# Altium schematic sheet pad.SchDoc(pad)
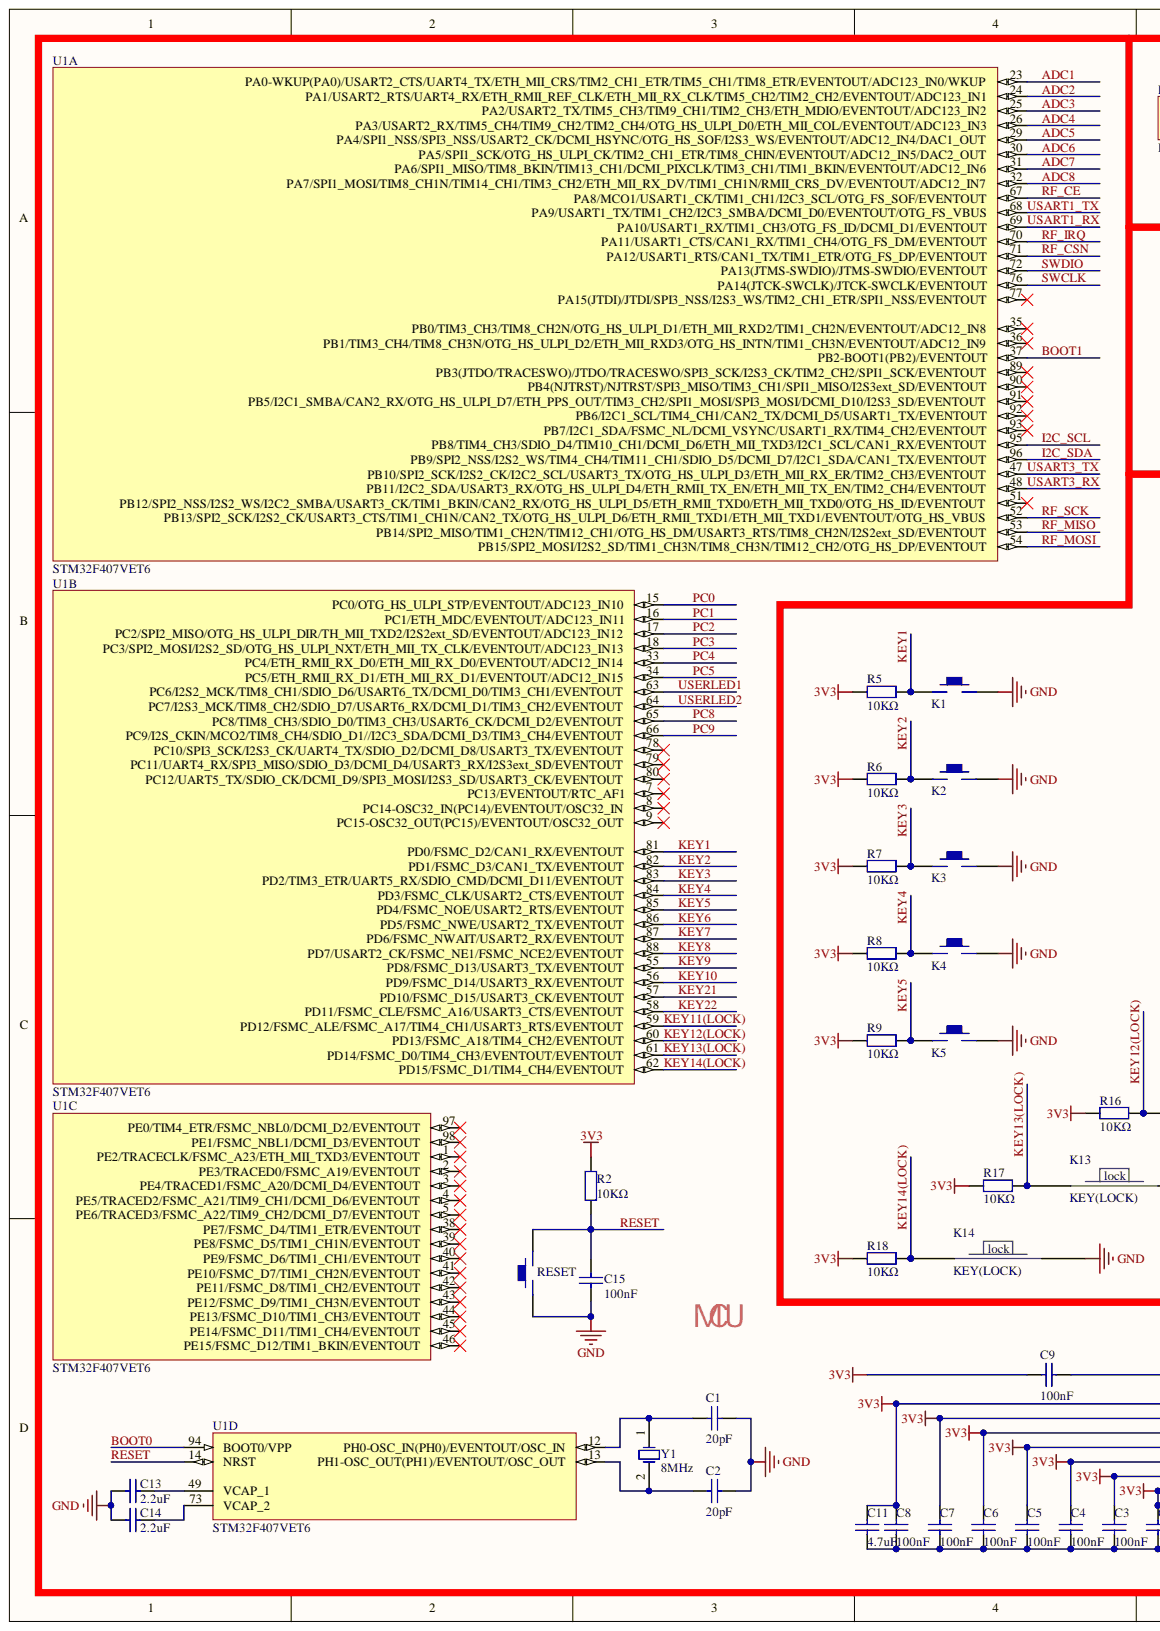
[diagram p0: pad.SchDoc, left]
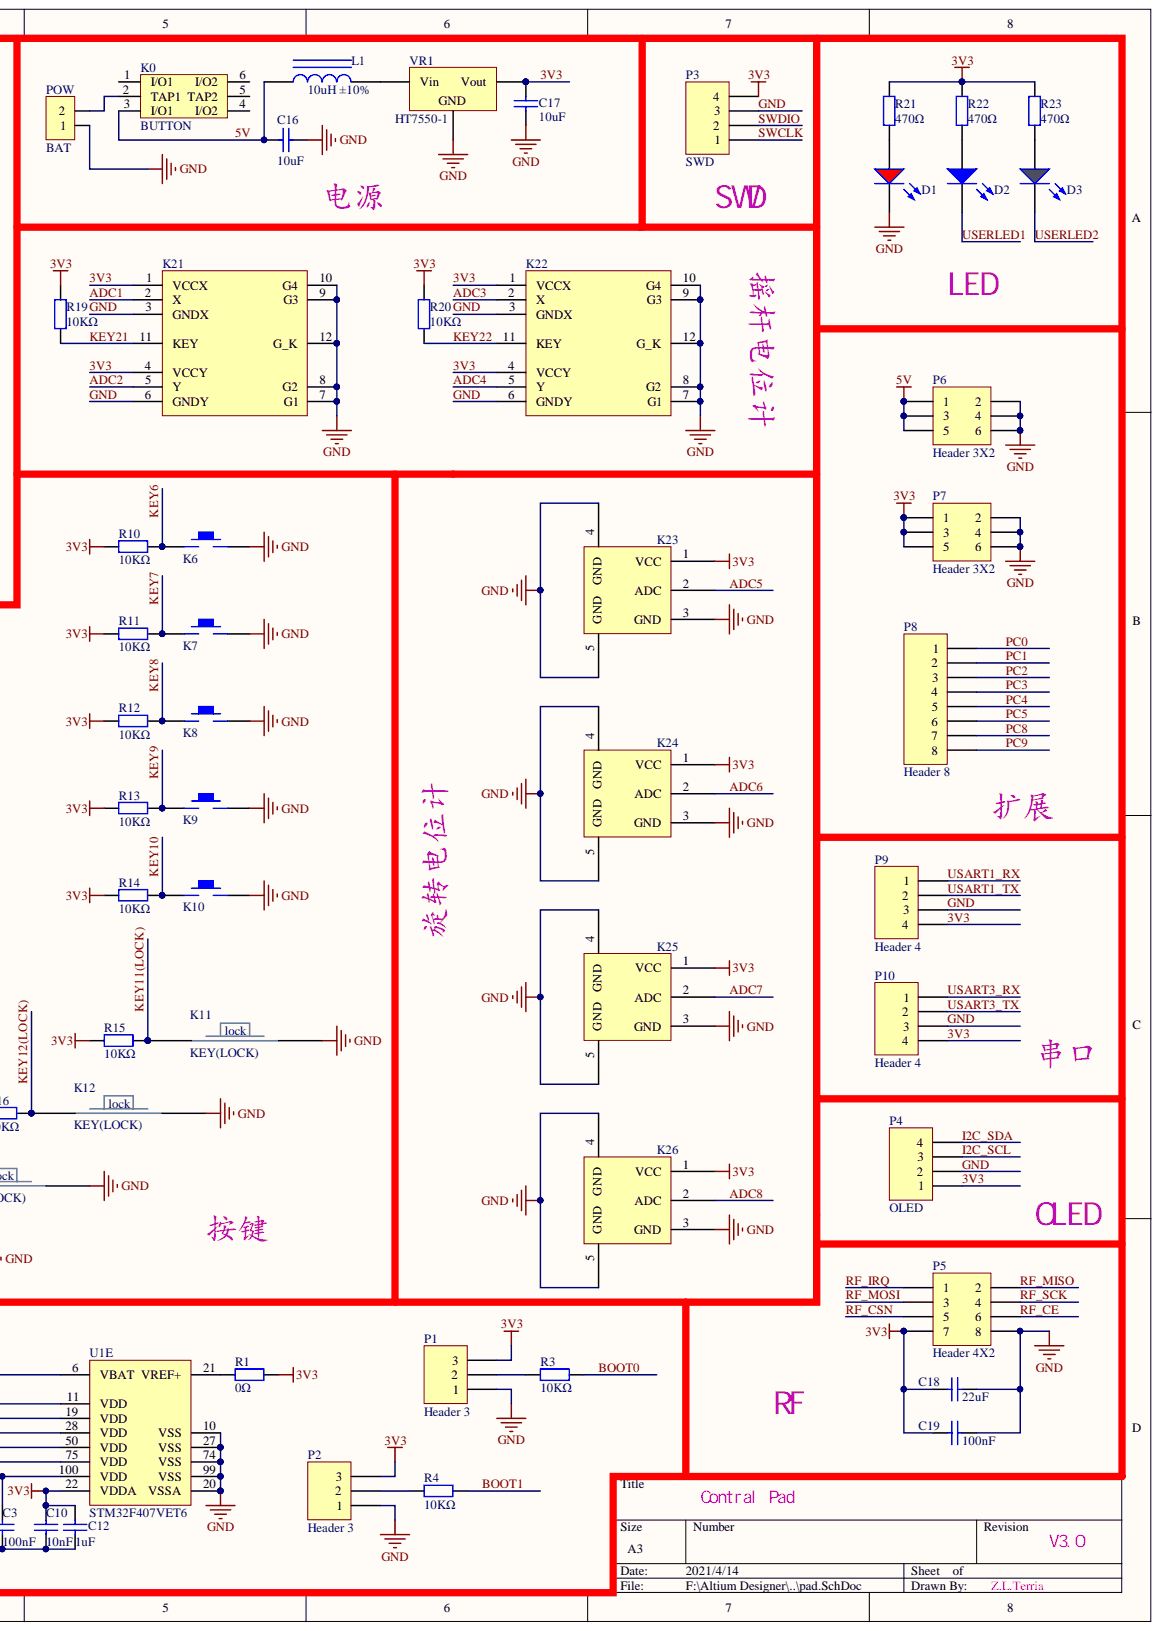
[diagram p1: pad.SchDoc, right]

## Parts
C1  20pF  [0603_C]
C2  20pF  [0603_C]
C3  100nF  [0603_C]
C4  100nF  [0603_C]
C5  100nF  [0603_C]
C6  100nF  [0603_C]
C7  100nF  [0603_C]
C8  100nF  [0603_C]
C9  100nF  [0603_C]
C10  10nF  [0603_C]
C11  4.7uF  [0603_C]
C12  1uF  [0603_C]
C13  2.2uF  [0603_C]
C14  2.2uF  [0603_C]
C15  100nF  [0603_C]
C16  10uF  [0603_C]
C17  10uF  [0603_C]
C18  22uF  [0603_C]
C19  100nF  [0603_C]
D1  红灯 0603  [0603_LED_S1]  (value not drawn)
D2  蓝灯 0603  [0603_LED_S1]  (value not drawn)
D3  绿灯 0603  [0603_LED_S1]  (value not drawn)
K0  BUTTON  [BTN8.5*8.5]
K1  KEY_M  [KEY_M]  (value not drawn)
K2  KEY_M  [KEY_M]  (value not drawn)
K3  KEY_M  [KEY_M]  (value not drawn)
K4  KEY_M  [KEY_M]  (value not drawn)
K5  KEY_M  [KEY_M]  (value not drawn)
K6  KEY_M  [KEY_M]  (value not drawn)
K7  KEY_M  [KEY_M]  (value not drawn)
K8  KEY_M  [KEY_M]  (value not drawn)
K9  KEY_M  [KEY_M]  (value not drawn)
K10  KEY_M  [KEY_M]  (value not drawn)
K11  KEY(LOCK)  [自锁按键]
K12  KEY(LOCK)  [自锁按键]
K13  KEY(LOCK)  [自锁按键]
K14  KEY(LOCK)  [自锁按键]
K21  摇杆电位计  [摇杆电位计]  (value not drawn)
K22  摇杆电位计  [摇杆电位计]
K23  KEY  [旋转电位计]  (value not drawn)
K24  KEY  [旋转电位计]  (value not drawn)
K25  KEY  [旋转电位计]  (value not drawn)
K26  KEY  [旋转电位计]  (value not drawn)
L1  10uH ±10%  [0603_L]
P1  Header 3  [HDR1X3]
P2  Header 3  [HDR1X3]
P3  SWD  [HDR1X4]
P4  OLED  [HDR1X4]
P5  Header 4X2  [HDR2X4]
P6  Header 3X2  [HDR2X3]
P7  Header 3X2  [HDR2X3]
P8  Header 8  [HDR1X8]
P9  Header 4  [HDR1X4]
P10  Header 4  [HDR1X4]
POW  BAT  [XH-2]
R1  0Ω  [0603_R]
R2  10KΩ  [0603_R]
R3  10KΩ  [0603_R]
R4  10KΩ  [0603_R]
R5  10KΩ  [0603_R]
R6  10KΩ  [0603_R]
R7  10KΩ  [0603_R]
R8  10KΩ  [0603_R]
R9  10KΩ  [0603_R]
R10  10KΩ  [0603_R]
R11  10KΩ  [0603_R]
R12  10KΩ  [0603_R]
R13  10KΩ  [0603_R]
R14  10KΩ  [0603_R]
R15  10KΩ  [0603_R]
R16  10KΩ  [0603_R]
R17  10KΩ  [0603_R]
R18  10KΩ  [0603_R]
R19  10KΩ  [0603_R]
R20  10KΩ  [0603_R]
R21  470Ω  [0603_R]
R22  470Ω  [0603_R]
R23  470Ω  [0603_R]
RESET  KEY_M  [KEY_M]  (value not drawn)
U1  STM32F407VET6  [LQFP-100_14x14x05P]  (parts A,B,C,D,E)
VR1  HT7550-1  [SOT-89(SOT-89-3)]
Y1  8MHz  [SMD-5032_2P]

## Nets
3V3: C3.2 C4.2 C5.2 C6.2 C7.2 C8.2 C9.2 C10.2 C11.2 C12.2 C17.1 C18.1 C19.1 K21.1(VCCX) K21.4(VCCY) K22.1(VCCX) K22.4(VCCY) K23.1(VCC) K24.1(VCC) K25.1(VCC) K26.1(VCC) P1.3 P2.3 P3.4 P4.1 P5.7 P7.1 P7.3 P7.5 P9.4 P10.4 R1.2 R2.2 R5.1 R6.1 R7.1 R8.1 R9.1 R10.1 R11.1 R12.1 R13.1 R14.1 R15.1 R16.1 R17.1 R18.1 R19.2 R20.2 R21.2 R22.2 R23.2 U1.11(VDD) U1.19(VDD) U1.22(VDDA) U1.28(VDD) U1.50(VDD) U1.75(VDD) U1.100(VDD) VR1.3
5V: C16.2 K0.3(I/O1) L1.1 P6.1 P6.3 P6.5
ADC1: K21.2(X) U1.23(PA0-WKUP(PA0)/USART2_CTS/UART4_TX/ETH_MII_CRS/TIM2_CH1_ETR/TIM5_CH1/TIM8_ETR/EVENTOUT/ADC123_IN0/WKUP)
ADC2: K21.5(Y) U1.24(PA1/USART2_RTS/UART4_RX/ETH_RMII_REF_CLK/ETH_MII_RX_CLK/TIM5_CH2/TIM2_CH2/EVENTOUT/ADC123_IN1)
ADC3: K22.2(X) U1.25(PA2/USART2_TX/TIM5_CH3/TIM9_CH1/TIM2_CH3/ETH_MDIO/EVENTOUT/ADC123_IN2)
ADC4: K22.5(Y) U1.26(PA3/USART2_RX/TIM5_CH4/TIM9_CH2/TIM2_CH4/OTG_HS_ULPI_D0/ETH_MII_COL/EVENTOUT/ADC123_IN3)
ADC5: K23.2(ADC) U1.29(PA4/SPI1_NSS/SPI3_NSS/USART2_CK/DCMI_HSYNC/OTG_HS_SOF/I2S3_WS/EVENTOUT/ADC12_IN4/DAC1_OUT)
ADC6: K24.2(ADC) U1.30(PA5/SPI1_SCK/OTG_HS_ULPI_CK/TIM2_CH1_ETR/TIM8_CHIN/EVENTOUT/ADC12_IN5/DAC2_OUT)
ADC7: K25.2(ADC) U1.31(PA6/SPI1_MISO/TIM8_BKIN/TIM13_CH1/DCMI_PIXCLK/TIM3_CH1/TIM1_BKIN/EVENTOUT/ADC12_IN6)
ADC8: K26.2(ADC) U1.32(PA7/SPI1_MOSI/TIM8_CH1N/TIM14_CH1/TIM3_CH2/ETH_MII_RX_DV/TIM1_CH1N/RMII_CRS_DV/EVENTOUT/ADC12_IN7)
BOOT0: R3.2 U1.94(BOOT0/VPP)
BOOT1: R4.2 U1.37(PB2-BOOT1(PB2)/EVENTOUT)
GND: C1.2 C2.2 C13.1 C14.1 C15.1 C16.1 C17.2 C18.2 C19.2 D1.K K1.2 K2.2 K3.2 K4.2 K5.2 K6.2 K7.2 K8.2 K9.2 K10.2 K11.1 K12.1 K13.1 K14.1 K21.3(GNDX) K21.6(GNDY) K21.7(G1) K21.8(G2) K21.9(G3) K21.10(G4) K21.12(G_K) K22.3(GNDX) K22.6(GNDY) K22.7(G1) K22.8(G2) K22.9(G3) K22.10(G4) K22.12(G_K) K23.3(GND) K23.4(GND) K23.5(GND) K24.3(GND) K24.4(GND) K24.5(GND) K25.3(GND) K25.4(GND) K25.5(GND) K26.3(GND) K26.4(GND) K26.5(GND) P1.1 P2.1 P3.3 P4.2 P5.8 P6.2 P6.4 P6.6 P7.2 P7.4 P7.6 P9.3 P10.3 POW.1 RESET.1 U1.10(VSS) U1.20(VSSA) U1.27(VSS) U1.74(VSS) U1.99(VSS) VR1.1
I2C_SCL: P4.3 U1.95(PB8/TIM4_CH3/SDIO_D4/TIM10_CH1/DCMI_D6/ETH_MII_TXD3/I2C1_SCL/CAN1_RX/EVENTOUT)
I2C_SDA: P4.4 U1.96(PB9/SPI2_NSS/I2S2_WS/TIM4_CH4/TIM11_CH1/SDIO_D5/DCMI_D7/I2C1_SDA/CAN1_TX/EVENTOUT)
KEY1: K1.1 R5.2 U1.81(PD0/FSMC_D2/CAN1_RX/EVENTOUT)
KEY2: K2.1 R6.2 U1.82(PD1/FSMC_D3/CAN1_TX/EVENTOUT)
KEY3: K3.1 R7.2 U1.83(PD2/TIM3_ETR/UART5_RX/SDIO_CMD/DCMI_D11/EVENTOUT)
KEY4: K4.1 R8.2 U1.84(PD3/FSMC_CLK/USART2_CTS/EVENTOUT)
KEY5: K5.1 R9.2 U1.85(PD4/FSMC_NOE/USART2_RTS/EVENTOUT)
KEY6: K6.1 R10.2 U1.86(PD5/FSMC_NWE/USART2_TX/EVENTOUT)
KEY7: K7.1 R11.2 U1.87(PD6/FSMC_NWAIT/USART2_RX/EVENTOUT)
KEY8: K8.1 R12.2 U1.88(PD7/USART2_CK/FSMC_NE1/FSMC_NCE2/EVENTOUT)
KEY9: K9.1 R13.2 U1.55(PD8/FSMC_D13/USART3_TX/EVENTOUT)
KEY10: K10.1 R14.2 U1.56(PD9/FSMC_D14/USART3_RX/EVENTOUT)
KEY11(LOCK): K11.2 R15.2 U1.59(PD12/FSMC_ALE/FSMC_A17/TIM4_CH1/USART3_RTS/EVENTOUT)
KEY12(LOCK): K12.2 R16.2 U1.60(PD13/FSMC_A18/TIM4_CH2/EVENTOUT)
KEY13(LOCK): K13.2 R17.2 U1.61(PD14/FSMC_D0/TIM4_CH3/EVENTOUT/EVENTOUT)
KEY14(LOCK): K14.2 R18.2 U1.62(PD15/FSMC_D1/TIM4_CH4/EVENTOUT)
KEY21: K21.11(KEY) R19.1 U1.57(PD10/FSMC_D15/USART3_CK/EVENTOUT)
KEY22: K22.11(KEY) R20.1 U1.58(PD11/FSMC_CLE/FSMC_A16/USART3_CTS/EVENTOUT)
PC0: P8.1 U1.15(PC0/OTG_HS_ULPI_STP/EVENTOUT/ADC123_IN10)
PC1: P8.2 U1.16(PC1/ETH_MDC/EVENTOUT/ADC123_IN11)
PC2: P8.3 U1.17(PC2/SPI2_MISO/OTG_HS_ULPI_DIR/TH_MII_TXD2/I2S2ext_SD/EVENTOUT/ADC123_IN12)
PC3: P8.4 U1.18(PC3/SPI2_MOSI/I2S2_SD/OTG_HS_ULPI_NXT/ETH_MII_TX_CLK/EVENTOUT/ADC123_IN13)
PC4: P8.5 U1.33(PC4/ETH_RMII_RX_D0/ETH_MII_RX_D0/EVENTOUT/ADC12_IN14)
PC5: P8.6 U1.34(PC5/ETH_RMII_RX_D1/ETH_MII_RX_D1/EVENTOUT/ADC12_IN15)
PC8: P8.7 U1.65(PC8/TIM8_CH3/SDIO_D0/TIM3_CH3/USART6_CK/DCMI_D2/EVENTOUT)
PC9: P8.8 U1.66(PC9/I2S_CKIN/MCO2/TIM8_CH4/SDIO_D1//I2C3_SDA/DCMI_D3/TIM3_CH4/EVENTOUT)
RESET: C15.2 R2.1 RESET.2 U1.14(NRST)
RF_CE: P5.6 U1.67(PA8/MCO1/USART1_CK/TIM1_CH1/I2C3_SCL/OTG_FS_SOF/EVENTOUT)
RF_CSN: P5.5 U1.71(PA12/USART1_RTS/CAN1_TX/TIM1_ETR/OTG_FS_DP/EVENTOUT)
RF_IRQ: P5.1 U1.70(PA11/USART1_CTS/CAN1_RX/TIM1_CH4/OTG_FS_DM/EVENTOUT)
RF_MISO: P5.2 U1.53(PB14/SPI2_MISO/TIM1_CH2N/TIM12_CH1/OTG_HS_DM/USART3_RTS/TIM8_CH2N/I2S2ext_SD/EVENTOUT)
RF_MOSI: P5.3 U1.54(PB15/SPI2_MOSI/I2S2_SD/TIM1_CH3N/TIM8_CH3N/TIM12_CH2/OTG_HS_DP/EVENTOUT)
RF_SCK: P5.4 U1.52(PB13/SPI2_SCK/I2S2_CK/USART3_CTS/TIM1_CH1N/CAN2_TX/OTG_HS_ULPI_D6/ETH_RMII_TXD1/ETH_MII_TXD1/EVENTOUT/OTG_HS_VBUS)
SWCLK: P3.1 U1.76(PA14(JTCK-SWCLK)/JTCK-SWCLK/EVENTOUT)
SWDIO: P3.2 U1.72(PA13(JTMS-SWDIO)/JTMS-SWDIO/EVENTOUT)
USART1_RX: P9.1 U1.69(PA10/USART1_RX/TIM1_CH3/OTG_FS_ID/DCMI_D1/EVENTOUT)
USART1_TX: P9.2 U1.68(PA9/USART1_TX/TIM1_CH2/I2C3_SMBA/DCMI_D0/EVENTOUT/OTG_FS_VBUS)
USART3_RX: P10.1 U1.48(PB11/I2C2_SDA/USART3_RX/OTG_HS_ULPI_D4/ETH_RMII_TX_EN/ETH_MII_TX_EN/TIM2_CH4/EVENTOUT)
USART3_TX: P10.2 U1.47(PB10/SPI2_SCK/I2S2_CK/I2C2_SCL/USART3_TX/OTG_HS_ULPI_D3/ETH_MII_RX_ER/TIM2_CH3/EVENTOUT)
USERLED1: D2.K U1.63(PC6/I2S2_MCK/TIM8_CH1/SDIO_D6/USART6_TX/DCMI_D0/TIM3_CH1/EVENTOUT)
USERLED2: D3.K U1.64(PC7/I2S3_MCK/TIM8_CH2/SDIO_D7/USART6_RX/DCMI_D1/TIM3_CH2/EVENTOUT)
NetC1_1: C1.1 U1.12(PH0-OSC_IN(PH0)/EVENTOUT/OSC_IN) Y1.1
NetC2_1: C2.1 U1.13(PH1-OSC_OUT(PH1)/EVENTOUT/OSC_OUT) Y1.2
NetC3_1: C3.1 C4.1 C5.1 C6.1 C7.1 C8.1 C10.1 C11.1 C12.1
NetC9_1: C9.1 U1.6(VBAT)
NetC13_2: C13.2 U1.49(VCAP_1)
NetC14_2: C14.2 U1.73(VCAP_2)
NetD1_A: D1.A R21.1
NetD2_A: D2.A R22.1
NetD3_A: D3.A R23.1
NetK0_2: K0.2(TAP1) POW.2
NetL1_2: L1.2 VR1.2
NetP1_2: P1.2 R3.1
NetP2_2: P2.2 R4.1
NetR1_1: R1.1 U1.21(VREF+)

Not connected: K0 1(I/O1) 4(I/O2) 5(TAP2) 6(I/O2); U1 1(PE2/TRACECLK/FSMC_A23/ETH_MII_TXD3/EVENTOUT) 2(PE3/TRACED0/FSMC_A19/EVENTOUT) 3(PE4/TRACED1/FSMC_A20/DCMI_D4/EVENTOUT) 4(PE5/TRACED2/FSMC_A21/TIM9_CH1/DCMI_D6/EVENTOUT) 5(PE6/TRACED3/FSMC_A22/TIM9_CH2/DCMI_D7/EVENTOUT) 7(PC13/EVENTOUT/RTC_AF1) 8(PC14-OSC32_IN(PC14)/EVENTOUT/OSC32_IN) 9(PC15-OSC32_OUT(PC15)/EVENTOUT/OSC32_OUT) 35(PB0/TIM3_CH3/TIM8_CH2N/OTG_HS_ULPI_D1/ETH_MII_RXD2/TIM1_CH2N/EVENTOUT/ADC12_IN8) 36(PB1/TIM3_CH4/TIM8_CH3N/OTG_HS_ULPI_D2/ETH_MII_RXD3/OTG_HS_INTN/TIM1_CH3N/EVENTOUT/ADC12_IN9) 38(PE7/FSMC_D4/TIM1_ETR/EVENTOUT) 39(PE8/FSMC_D5/TIM1_CH1N/EVENTOUT) 40(PE9/FSMC_D6/TIM1_CH1/EVENTOUT) 41(PE10/FSMC_D7/TIM1_CH2N/EVENTOUT) 42(PE11/FSMC_D8/TIM1_CH2/EVENTOUT) 43(PE12/FSMC_D9/TIM1_CH3N/EVENTOUT) 44(PE13/FSMC_D10/TIM1_CH3/EVENTOUT) 45(PE14/FSMC_D11/TIM1_CH4/EVENTOUT) 46(PE15/FSMC_D12/TIM1_BKIN/EVENTOUT) 51(PB12/SPI2_NSS/I2S2_WS/I2C2_SMBA/USART3_CK/TIM1_BKIN/CAN2_RX/OTG_HS_ULPI_D5/ETH_RMII_TXD0/ETH_MII_TXD0/OTG_HS_ID/EVENTOUT) 77(PA15(JTDI)/JTDI/SPI3_NSS/I2S3_WS/TIM2_CH1_ETR/SPI1_NSS/EVENTOUT) 78(PC10/SPI3_SCK/I2S3_CK/UART4_TX/SDIO_D2/DCMI_D8/USART3_TX/EVENTOUT) 79(PC11/UART4_RX/SPI3_MISO/SDIO_D3/DCMI_D4/USART3_RX/I2S3ext_SD/EVENTOUT) 80(PC12/UART5_TX/SDIO_CK/DCMI_D9/SPI3_MOSI/I2S3_SD/USART3_CK/EVENTOUT) 89(PB3(JTDO/TRACESWO)/JTDO/TRACESWO/SPI3_SCK/I2S3_CK/TIM2_CH2/SPI1_SCK/EVENTOUT) 90(PB4(NJTRST)/NJTRST/SPI3_MISO/TIM3_CH1/SPI1_MISO/I2S3ext_SD/EVENTOUT) 91(PB5/I2C1_SMBA/CAN2_RX/OTG_HS_ULPI_D7/ETH_PPS_OUT/TIM3_CH2/SPI1_MOSI/SPI3_MOSI/DCMI_D10/I2S3_SD/EVENTOUT) 92(PB6/I2C1_SCL/TIM4_CH1/CAN2_TX/DCMI_D5/USART1_TX/EVENTOUT) 93(PB7/I2C1_SDA/FSMC_NL/DCMI_VSYNC/USART1_RX/TIM4_CH2/EVENTOUT) 97(PE0/TIM4_ETR/FSMC_NBL0/DCMI_D2/EVENTOUT) 98(PE1/FSMC_NBL1/DCMI_D3/EVENTOUT)

## Library data of the parts
C1 C2 = 0603 20pF (200) 5% 50V: 贴片电容; SuppliersPartNumber: C1648; Supplier: LC; Package: 0603; Library Name: Cap.SchLib; ComponentLink1URL: http://www.szlcsc.com/product/details_2000.html; ComponentLink1Description: 供应商链接; Component Kind: Standard
C3-C9 C15 C19 = 0603 100nF (104) 10% 50V: 贴片电容; SuppliersPartNumber: C14663; Supplier: LC; Package: 0603; Library Name: Cap.SchLib; ComponentLink1URL: http://www.szlcsc.com/product/details_15331.html; ComponentLink1Description: 供应商链接; Component Kind: Standard
C10 = 0603 10nF (103) 10% 50V: 贴片电容; SuppliersPartNumber: C57112; Supplier: LC; Package: 0603; Library Name: Cap.SchLib; ComponentLink1URL: http://www.szlcsc.com/product/details_58143.html; ComponentLink1Description: 供应商链接; Component Kind: Standard
C11 = 0603 4.7uF (475) 10% 16V: 贴片电容; SuppliersPartNumber: C19666; Supplier: LC; Package: 0603; Library Name: Cap.SchLib; ComponentLink1URL: http://www.szlcsc.com/product/details_20375.html; ComponentLink1Description: 供应商链接; Component Kind: Standard
C12 = 0603 1uF (105) 10% 50V: 贴片电容; SuppliersPartNumber: C15849; Supplier: LC; Package: 0603; Library Name: Cap.SchLib; ComponentLink1URL: http://www.szlcsc.com/product/details_16531.html; ComponentLink1Description: 供应商链接; Component Kind: Standard
C13 C14 = 0603 2.2uF (225) 10% 16V: 贴片电容; SuppliersPartNumber: C23630; Supplier: LC; Package: 0603; Library Name: Cap.SchLib; ComponentLink1URL: http://www.szlcsc.com/product/details_24366.html; ComponentLink1Description: 供应商链接; Component Kind: Standard
C16 C17 = 0603 10uF (106) 10% 10V: 贴片电容; SuppliersPartNumber: C19702; Supplier: LC; Package: 0603; Library Name: Cap.SchLib; ComponentLink1URL: http://www.szlcsc.com/product/details_20411.html; ComponentLink1Description: 供应商链接; Component Kind: Standard
C18 = 0603 22uF (226) 20% 6.3V: 贴片电容; SuppliersPartNumber: C59461; Supplier: LC; Package: 0603; Library Name: Cap.SchLib; ComponentLink1URL: http://www.szlcsc.com/product/details_60514.html; ComponentLink1Description: 供应商链接; Component Kind: Standard
D1 = 0603 红灯: 发光二极管; SuppliersPartNumber: C2286; Supplier: LC; Package: 0603; Library Name: LED.SchLib; ComponentLink1URL: http://www.szlcsc.com/product/details_2659.html; ComponentLink1Description: 供应商链接; Component Kind: Standard
D2 = 0603 蓝灯: 发光二极管; SuppliersPartNumber: C72041; Supplier: LC; Package: 0603; Library Name: LED.SchLib; ComponentLink1URL: http://www.szlcsc.com/product/details_73150.html; ComponentLink1Description: 供应商链接; Component Kind: Standard
D3 = 0603 绿灯: 发光二极管; SuppliersPartNumber: C72043; Supplier: LC; Package: 0603; Library Name: LED.SchLib; ComponentLink1URL: http://www.szlcsc.com/product/details_73152.html; ComponentLink1Description: 供应商链接; Component Kind: Standard
K11-K14 = 自锁按键: Component Kind: Standard; Library Name: 摇杆电位计.SchLib
K23-K26 = 旋转电位计: Component Kind: Standard; Library Name: 摇杆电位计.SchLib
L1 = 0603 10uH 10%: 贴片电感; SuppliersPartNumber: C1035; Supplier: LC; Package: 0603; Library Name: IUD.SchLib; ComponentLink1URL: http://www.szlcsc.com/product/details_1387.html; ComponentLink1Description: 供应商链接; Component Kind: Standard
P1 P2 = Header 3: Header, 3-Pin; Signal Integrity: Connector; Library Name: Miscellaneous Connectors.IntLib; LatestRevisionNote: Re-released for DXP Platform.; LatestRevisionDate: 17-Jul-2002; Component Kind: Standard; Publisher: Altium Limited
P3 P4 P9 P10 = Header 4: Header, 4-Pin; Signal Integrity: Connector; Library Name: Miscellaneous Connectors.IntLib; LatestRevisionNote: Re-released for DXP Platform.; LatestRevisionDate: 17-Jul-2002; Component Kind: Standard; Publisher: Altium Limited
P5 = Header 4X2: Header, 4-Pin, Dual row; Signal Integrity: Connector; Library Name: Miscellaneous Connectors.IntLib; LatestRevisionNote: Re-released for DXP Platform.; LatestRevisionDate: 17-Jul-2002; Component Kind: Standard; Publisher: Altium Limited
P6 P7 = Header 3X2: Header, 3-Pin, Dual row; Signal Integrity: Connector; Library Name: Miscellaneous Connectors.IntLib; LatestRevisionNote: Re-released for DXP Platform.; LatestRevisionDate: 17-Jul-2002; Component Kind: Standard; Publisher: Altium Limited
P8 = Header 8: Header, 8-Pin; Signal Integrity: Connector; Library Name: Miscellaneous Connectors.IntLib; LatestRevisionNote: Re-released for DXP Platform.; LatestRevisionDate: 17-Jul-2002; Component Kind: Standard; Publisher: Altium Limited
POW = CON2: Connector; Component Kind: Standard; Library Name: 连接器件.SchLib
R1 = 0603 0Ω (0R0) 1%: 贴片电阻; SuppliersPartNumber: C21189; Supplier: LC; Package: 0603; Library Name: RES.SchLib; ComponentLink1URL: http://www.szlcsc.com/product/details_21903.html; ComponentLink1Description: 供应商链接; Component Kind: Standard
R2-R20 = 0603 10KΩ (1002) 1%: 贴片电阻; SuppliersPartNumber: C25804; Supplier: LC; Package: 0603; Library Name: RES.SchLib; ComponentLink1URL: http://www.szlcsc.com/product/details_26547.html; ComponentLink1Description: 供应商链接; Component Kind: Standard
R21-R23 = 0603 470Ω (4700) 1%: 贴片电阻; SuppliersPartNumber: C23179; Supplier: LC; Package: 0603; Library Name: RES.SchLib; ComponentLink1URL: http://www.szlcsc.com/product/details_23906.html; ComponentLink1Description: 供应商链接; Component Kind: Standard
U1 = STM32F407VET6: ARM; SuppliersPartNumber: C28730; Supplier: LC; Package: LQFP-100_14x14x05P; Library Name: MCU.SchLib; ComponentLink1URL: http://www.szlcsc.com/product/details_29482.html; ComponentLink1Description: 供应商链接; Component Kind: Standard
VR1 = SOT-89 HT7550-1: 低压差线性稳压(LDO); SuppliersPartNumber: C16106; Supplier: LC; Package: SOT-89; Library Name: LDO.SchLib; ComponentLink1URL: http://www.szlcsc.com/product/details_16788.html; ComponentLink1Description: 供应商链接; Component Kind: Standard
Y1 = SMD-5032_2P 8MHz 20ppm 20pF: 贴片晶振; SuppliersPartNumber: C115962; Supplier: LC; Package: SMD-5032_2P; Library Name: CrystalOscillator.SchLib; ComponentLink1URL: http://www.szlcsc.com/product/details_117214.html; ComponentLink1Description: 供应商链接; Component Kind: Standard
K0 = BUTTON: Library Name: Explorer STM32F407封装库.IntLib; Component Kind: Standard
K1-K10 RESET = KEY_M: Library Name: Explorer STM32F407封装库.IntLib; Component Kind: Standard
K21 K22 = 摇杆电位计: Library Name: 摇杆电位计.SchLib; Component Kind: Standard
